# Altium schematic sheet AD936X_RF.SchDoc(AD936X_RF)
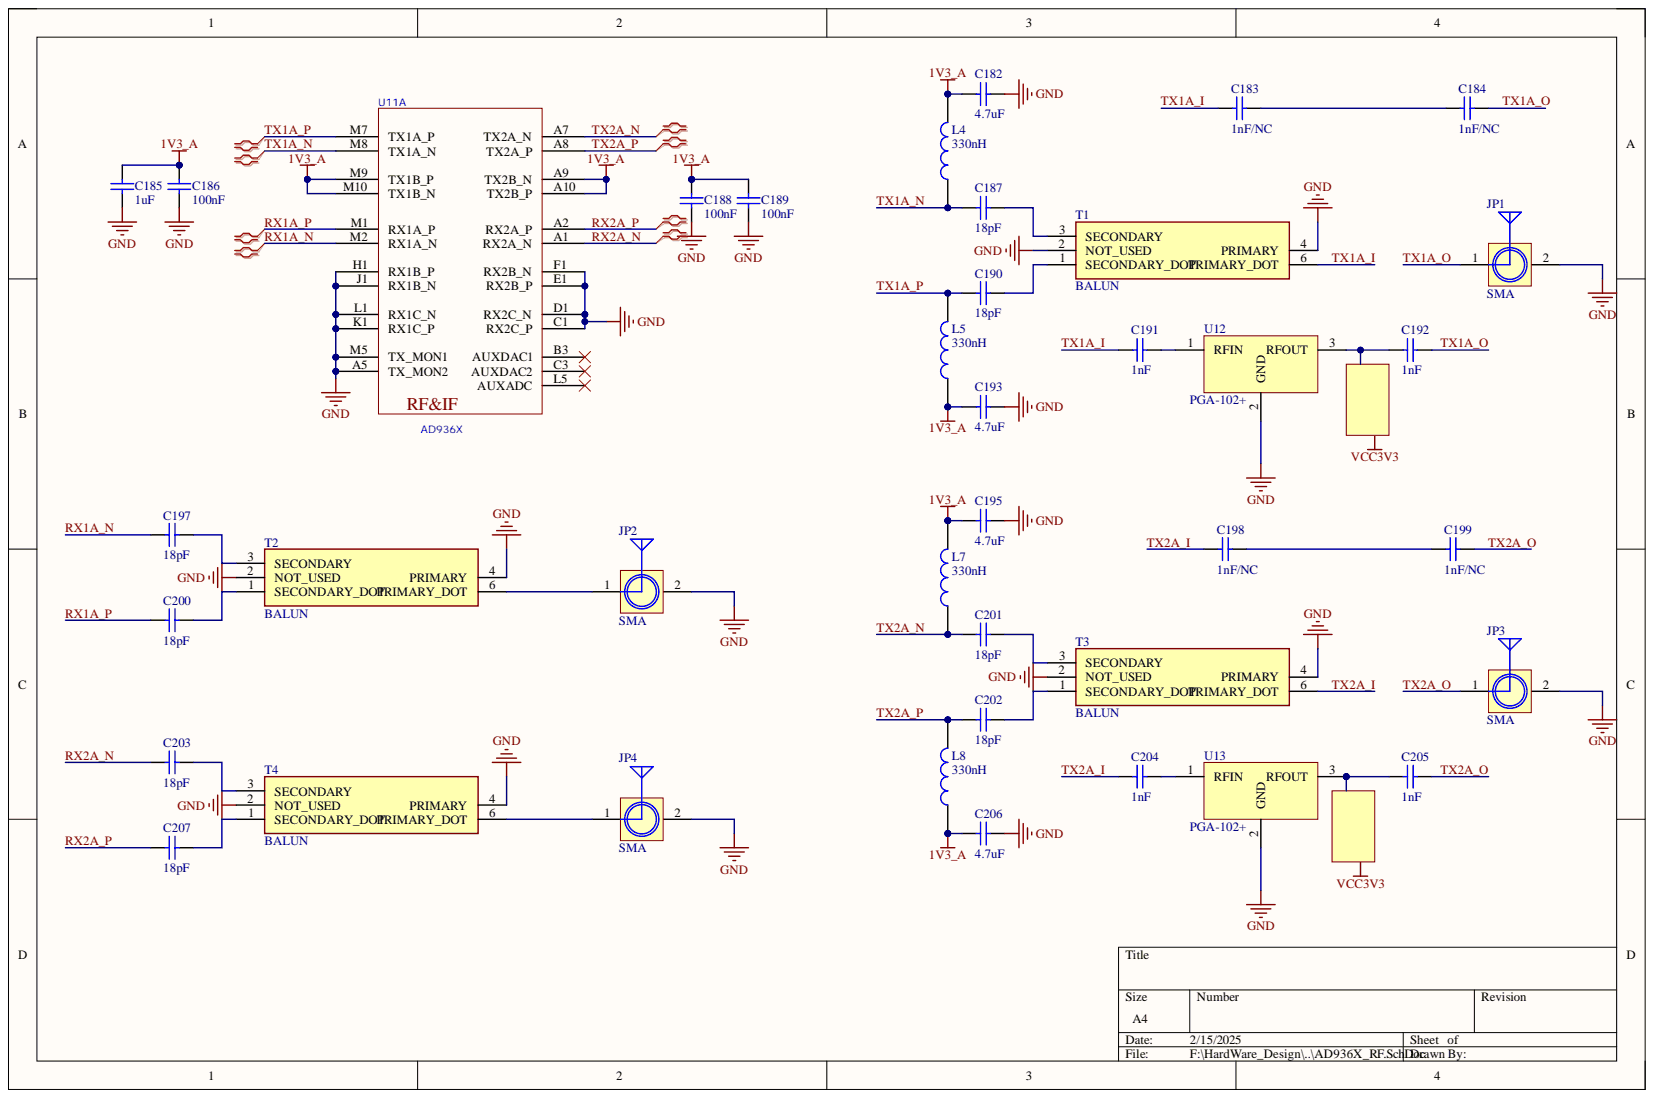
[diagram p0: AD936X_RF.SchDoc]

## Parts
C182  4.7uF  [C 0603_L]
C183  1nF/NC  [C 0402_L]
C184  1nF/NC  [C 0402_L]
C185  1uF  [C 0402_L]
C186  100nF  [C 0402_L]
C187  18pF  [C 0402_L]
C188  100nF  [C 0402_L]
C189  100nF  [C 0402_L]
C190  18pF  [C 0402_L]
C191  1nF  [C 0402_L]
C192  1nF  [C 0402_L]
C193  4.7uF  [C 0603_L]
C195  4.7uF  [C 0603_L]
C197  18pF  [C 0402_L]
C198  1nF/NC  [C 0402_L]
C199  1nF/NC  [C 0402_L]
C200  18pF  [C 0402_L]
C201  18pF  [C 0402_L]
C202  18pF  [C 0402_L]
C203  18pF  [C 0402_L]
C204  1nF  [C 0402_L]
C205  1nF  [C 0402_L]
C206  4.7uF  [C 0603_L]
C207  18pF  [C 0402_L]
JP1  SMA  [SMA-KE - 0.5mm]
JP2  SMA  [SMA-KE - 0.5mm]
JP3  SMA  [SMA-KE - 0.5mm]
JP4  SMA  [SMA-KE - 0.5mm]
L4  330nH  [L 0402]
L5  330nH  [L 0402]
L7  330nH  [L 0402]
L8  330nH  [L 0402]
T1  BALUN  [TCM163AX2]
T2  BALUN  [TCM163AX2]
T3  BALUN  [TCM163AX2]
T4  BALUN  [TCM163AX2]
U11  AD936X  [BGA144C80P12X12_1000X1000X170]  (part A)
U12  PGA-102+  [SOT89]
U13  PGA-102+  [SOT89]

## Nets
1V3_A: C182.1 C185.2 C186.2 C188.2 C189.2 C193.2 C195.1 C206.2 L4.2 L5.1 L7.2 L8.1 U11.A9(TX2B_N) U11.A10(TX2B_P) U11.M9(TX1B_P) U11.M10(TX1B_N)
GND: C182.2 C185.1 C186.1 C188.1 C189.1 C193.1 C195.2 C206.1 JP1.2 JP2.2 JP3.2 JP4.2 T1.2(NOT_USED) T1.4(PRIMARY) T2.2(NOT_USED) T2.4(PRIMARY) T3.2(NOT_USED) T3.4(PRIMARY) T4.2(NOT_USED) T4.4(PRIMARY) U11.A5(TX_MON2) U11.C1(RX2C_P) U11.D1(RX2C_N) U11.E1(RX2B_P) U11.F1(RX2B_N) U11.H1(RX1B_P) U11.J1(RX1B_N) U11.K1(RX1C_P) U11.L1(RX1C_N) U11.M5(TX_MON1) U12.2 U13.2
RX1A_N: C197.1 U11.M2(RX1A_N)
RX1A_P: C200.1 U11.M1(RX1A_P)
RX2A_N: C203.1 U11.A1(RX2A_N)
RX2A_P: C207.1 U11.A2(RX2A_P)
TX1A_I: C183.1 C191.1 T1.6(PRIMARY_DOT)
TX1A_N: C187.1 L4.1 U11.M8(TX1A_N)
TX1A_O: C184.2 C192.2 JP1.1
TX1A_P: C190.1 L5.2 U11.M7(TX1A_P)
TX2A_I: C198.1 C204.1 T3.6(PRIMARY_DOT)
TX2A_N: C201.1 L7.1 U11.A7(TX2A_N)
TX2A_O: C199.2 C205.2 JP3.1
TX2A_P: C202.1 L8.2 U11.A8(TX2A_P)
NetC183_2: C183.2 C184.1
NetC187_2: C187.2 T1.3(SECONDARY)
NetC190_2: C190.2 T1.1(SECONDARY_DOT)
NetC191_2: C191.2 U12.1
NetC192_1: C192.1 U12.3
NetC197_2: C197.2 T2.3(SECONDARY)
NetC198_2: C198.2 C199.1
NetC200_2: C200.2 T2.1(SECONDARY_DOT)
NetC201_2: C201.2 T3.3(SECONDARY)
NetC202_2: C202.2 T3.1(SECONDARY_DOT)
NetC203_2: C203.2 T4.3(SECONDARY)
NetC204_2: C204.2 U13.1
NetC205_1: C205.1 U13.3
NetC207_2: C207.2 T4.1(SECONDARY_DOT)
NetJP2_1: JP2.1 T2.6(PRIMARY_DOT)
NetJP4_1: JP4.1 T4.6(PRIMARY_DOT)
VCC3V3:

Not connected: U11 B3(AUXDAC1) C3(AUXDAC2) L5(AUXADC)

## Library data of the parts
C182-C193 C195 C197-C207 = Cap: 贴片电容; Component Kind: Standard; Library Name: A_Schlib.SchLib
  C182 C193 C195 C206: value: 4.7uF
  C183 C184 C198 C199: value: 1nF/NC
  C185: value: 1uF
  C186 C188 C189: value: 100nF
  C187 C190 C197 C200-C203 C207: value: 18pF
  C191 C192 C204 C205: value: 1nF
JP1-JP4 = SMA: SMA-2.4G射频天线接口; 版权归属: 源创客; BOM名称: SMA-2.4G射频天线接口; Component Kind: Standard; Library Name: A_Schlib.SchLib; 学习资源: www.ooocke.com
L4 L5 L7 L8 = 电感: 包含功率; Component Kind: Standard; Library Name: A_Schlib.SchLib
T1-T4 = TC1-1-13M+: Transformer; Manufacturer_Name: Mini-Circuits; Component Kind: Standard; Datasheet Link: https://datasheet.datasheetarchive.com/originals/distributors/Datasheets-28/DSA-546322.pdf; Height: 4.06mm; Library Name: SamacSys.SchLib; Manufacturer_Part_Number: TC1-1-13M+; Mouser Part Number: 139-TC1-1-13M; Mouser Price/Stock: https://www.mouser.co.uk/ProductDetail/Mini-Circuits/TC1-1-13M%2b?qs=xZ%2FP%252Ba9zWqYek%252BZGAF2fWg%3D%3D
U11 = AD936X: Component Kind: Standard; Library Name: A_Schlib.SchLib
U12 U13 = PGA-102+: Component Kind: Standard; Library Name: A_Schlib.SchLib
